# Altium schematic sheet Sheet3.SchDoc
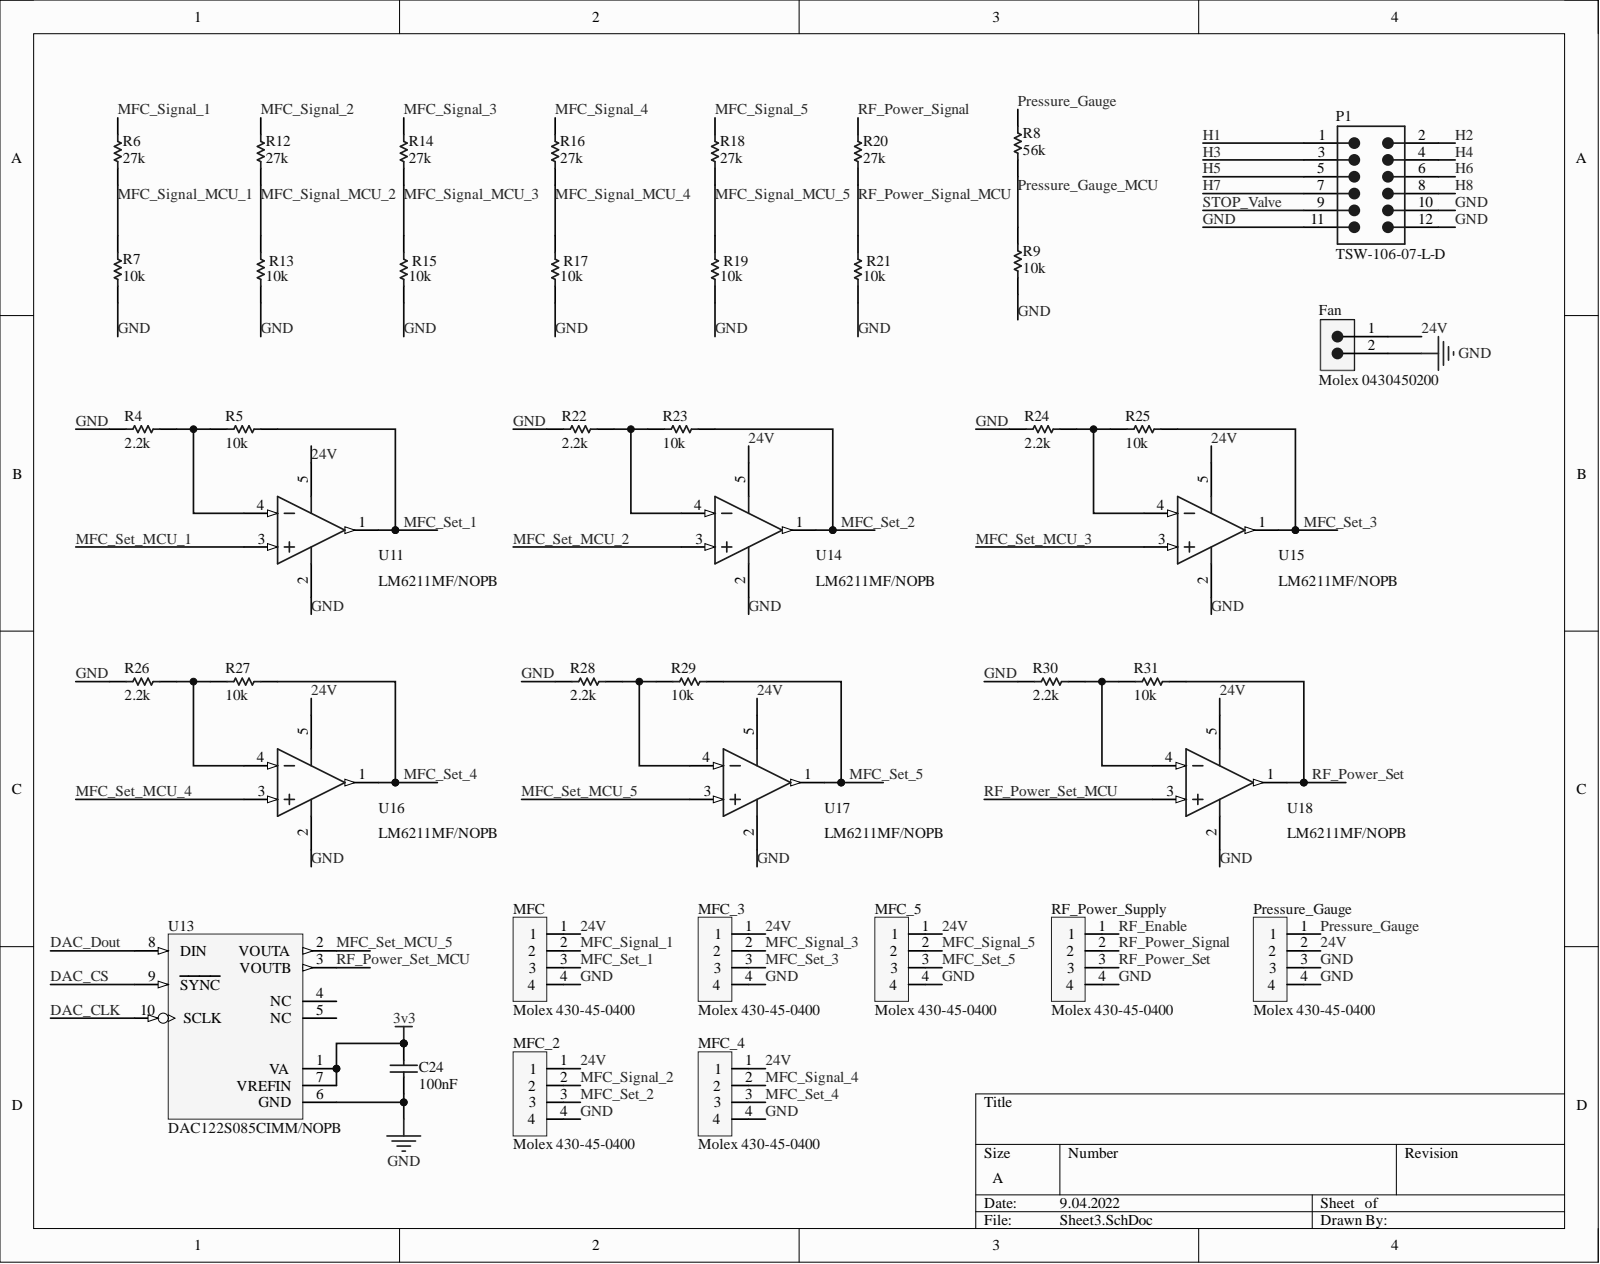
[diagram p0: Sheet3.SchDoc]

## Parts
C24  100nF  [CAPC1005X56X25NL10T15]
Fan  Molex 0430450200  [PCB-7hc2nr5bn4tgoucwa5ir-1]
MFC  Molex 430-45-0400  Manufacturer Part Number: 430-45-0400  [PCB-pgblzby65kiptvzjm08f-1]
MFC_2  Molex 430-45-0400  Manufacturer Part Number: 430-45-0400  [PCB-pgblzby65kiptvzjm08f-1]
MFC_3  Molex 430-45-0400  Manufacturer Part Number: 430-45-0400  [PCB-pgblzby65kiptvzjm08f-1]
MFC_4  Molex 430-45-0400  Manufacturer Part Number: 430-45-0400  [PCB-pgblzby65kiptvzjm08f-1]
MFC_5  Molex 430-45-0400  Manufacturer Part Number: 430-45-0400  [PCB-pgblzby65kiptvzjm08f-1]
P1  TSW-106-07-L-D  [SMTC-TSW-106-07-X-D]
Pressure_Gauge  Molex 430-45-0400  Manufacturer Part Number: 430-45-0400  [PCB-pgblzby65kiptvzjm08f-1]
R4  2.2k  [PCB-xna89c7rj2sv02b80bkg-1]
R5  10k  [PCB-xna89c7rj2sv02b80bkg-1]
R6  27k  [PCB-xna89c7rj2sv02b80bkg-1]
R7  10k  [PCB-xna89c7rj2sv02b80bkg-1]
R8  56k  [PCB-xna89c7rj2sv02b80bkg-1]
R9  10k  [PCB-xna89c7rj2sv02b80bkg-1]
R12  27k  [PCB-xna89c7rj2sv02b80bkg-1]
R13  10k  [PCB-xna89c7rj2sv02b80bkg-1]
R14  27k  [PCB-xna89c7rj2sv02b80bkg-1]
R15  10k  [PCB-xna89c7rj2sv02b80bkg-1]
R16  27k  [PCB-xna89c7rj2sv02b80bkg-1]
R17  10k  [PCB-xna89c7rj2sv02b80bkg-1]
R18  27k  [PCB-xna89c7rj2sv02b80bkg-1]
R19  10k  [PCB-xna89c7rj2sv02b80bkg-1]
R20  27k  [PCB-xna89c7rj2sv02b80bkg-1]
R21  10k  [PCB-xna89c7rj2sv02b80bkg-1]
R22  2.2k  [PCB-xna89c7rj2sv02b80bkg-1]
R23  10k  [PCB-xna89c7rj2sv02b80bkg-1]
R24  2.2k  [PCB-xna89c7rj2sv02b80bkg-1]
R25  10k  [PCB-xna89c7rj2sv02b80bkg-1]
R26  2.2k  [PCB-xna89c7rj2sv02b80bkg-1]
R27  10k  [PCB-xna89c7rj2sv02b80bkg-1]
R28  2.2k  [PCB-xna89c7rj2sv02b80bkg-1]
R29  10k  [PCB-xna89c7rj2sv02b80bkg-1]
R30  2.2k  [PCB-xna89c7rj2sv02b80bkg-1]
R31  10k  [PCB-xna89c7rj2sv02b80bkg-1]
RF_Power_Supply  Molex 430-45-0400  Manufacturer Part Number: 430-45-0400  [PCB-pgblzby65kiptvzjm08f-1]
U11  LM6211MF/NOPB  [MF05A_M]
U13  DAC122S085CIMM/NOPB  [MUB10A_M]
U14  LM6211MF/NOPB  [MF05A_M]
U15  LM6211MF/NOPB  [MF05A_M]
U16  LM6211MF/NOPB  [MF05A_M]
U17  LM6211MF/NOPB  [MF05A_M]
U18  LM6211MF/NOPB  [MF05A_M]

## Nets
3v3: C24.2 U13.1(VA) U13.7(VREFIN)
24V: Fan.1 MFC.1 MFC_2.1 MFC_3.1 MFC_4.1 MFC_5.1 Pressure_Gauge.2 U11.5 U14.5 U15.5 U16.5 U17.5 U18.5
DAC_CLK: U13.10(SCLK)
DAC_CS: U13.9(SYNC)
DAC_Dout: U13.8(DIN)
GND: C24.1 Fan.2 MFC.4 MFC_2.4 MFC_3.4 MFC_4.4 MFC_5.4 P1.10 P1.11 P1.12 Pressure_Gauge.3 Pressure_Gauge.4 R4.2 R7.2 R9.2 R13.2 R15.2 R17.2 R19.2 R21.2 R22.2 R24.2 R26.2 R28.2 R30.2 RF_Power_Supply.4 U11.2 U13.6(GND) U14.2 U15.2 U16.2 U17.2 U18.2
H1: P1.1
H2: P1.2
H3: P1.3
H4: P1.4
H5: P1.5
H6: P1.6
H7: P1.7
H8: P1.8
MFC_Set_1: MFC.3 R5.1 U11.1
MFC_Set_2: MFC_2.3 R23.1 U14.1
MFC_Set_3: MFC_3.3 R25.1 U15.1
MFC_Set_4: MFC_4.3 R27.1 U16.1
MFC_Set_5: MFC_5.3 R29.1 U17.1
MFC_Set_MCU_1: U11.3
MFC_Set_MCU_2: U14.3
MFC_Set_MCU_3: U15.3
MFC_Set_MCU_4: U16.3
MFC_Set_MCU_5: U13.2(VOUTA) U17.3
MFC_Signal_1: MFC.2 R6.1
MFC_Signal_2: MFC_2.2 R12.1
MFC_Signal_3: MFC_3.2 R14.1
MFC_Signal_4: MFC_4.2 R16.1
MFC_Signal_5: MFC_5.2 R18.1
MFC_Signal_MCU_1: R6.2 R7.1
MFC_Signal_MCU_2: R12.2 R13.1
MFC_Signal_MCU_3: R14.2 R15.1
MFC_Signal_MCU_4: R16.2 R17.1
MFC_Signal_MCU_5: R18.2 R19.1
Pressure_Gauge: Pressure_Gauge.1 R8.1
Pressure_Gauge_MCU: R8.2 R9.1
RF_Enable: RF_Power_Supply.1
RF_Power_Set: R31.1 RF_Power_Supply.3 U18.1
RF_Power_Set_MCU: U13.3(VOUTB) U18.3
RF_Power_Signal: R20.1 RF_Power_Supply.2
RF_Power_Signal_MCU: R20.2 R21.1
STOP_Valve: P1.9
NetR4_1: R4.1 R5.2 U11.4
NetR22_1: R22.1 R23.2 U14.4
NetR24_1: R24.1 R25.2 U15.4
NetR26_1: R26.1 R27.2 U16.4
NetR28_1: R28.1 R29.2 U17.4
NetR30_1: R30.1 R31.2 U18.4

Not connected: U13 4(NC) 5(NC)

## Library data of the parts
C24 = CMP-10875562-2: Capacitor 0.1 uF 16 Volt Y5 Volt -20% To 80% Surface Mount 0402 Paper Tape and Reel; Capacitance: 1e-07 F; Capacitance Tolerance: +80/-20%; Dielectric Characteristic: Y5V; Dielectric Material: Ceramic Multilayer; Lead-Free Status: Lead Free; Voltage Rating (DC): 16.0 V; Insulation Resistance: 1e+11 ?; Case/Package: 0402; Component Kind: Standard; Library Name: CommunityVault; Mounting Style: Surface Mount; Number of Pins: 2; Octopart Url: https://octopart.com/0402yg104zat2a-avx+interconnect+%2F+elco-38554; OctopartID: 7a36b33cc9caa5f1; Packaging: Cut Tape (CT); REACH SVHC Compliance: No SVHC; RoHS: Compliant; Size-Height: 0.00056 m; Size-Length: 0.001 m; Size-Thickness: 0.0008636 m; Size-Width: 0.0005 m
Fan = CMP-60dea9f3c213bf19-4: Conn Unshrouded Header HDR 2 POS 2.54mm Solder ST Thru-Hole Bag; Current Rating: 3.0 A; Component Kind: Standard; Library Name: CommunityVault
MFC MFC_2-MFC_5 Pressure_Gauge RF_Power_Supply = CMP-054fcd799a96da88-1: Conector Hembra Micro Fit 3.0 Acodado 2x2 Vias Pcb High Temperature 43045-0400 Molex; Current Rating: 5.0 A; Gender: Male; Glow Wire Compliant: Compliant; Lead-Free Status: Lead Free; Mated Height: 0.01727 m; Number of Circuits: 4; Pin Pitch: 0.003 m; Voltage Rating (AC): 250.0 V; Color: Black; Component Kind: Standard; Contact Material: Brass; Contact Plating: Tin; Flammability Rating: UL 94 V-0; Halogen Free Status: Low Halogen; Housing Color: Black; Housing Material: Plastic; Library Name: CommunityVault; Lifecycle Status: Active; Material: Polyester; Mounting Style: Solder, PCB; Number of Contacts: 4; Number of Positions: 4; Number of Rows: 2; Octopart URL: http://octopart.com/430-45-0400-molex-20757183; OctopartID: 054fcd799a96da88; Orientation: Right Angle; Packaging: Tray, Bulk; REACH SVHC Compliance: No SVHC; RoHS: Compliant; Size-Diameter: 0.003 m; Size-Height: 0.00737 m; Size-Length: 0.00965 m; Size-Width: 0.0099 m
P1 = CMP-4485796-2: 0.025" SQ Post Header, Through-hole, Vertical, -55 to 125 degC, 2.54 mm Pitch, 12-Pin, Male, RoHS; Lead-Free Status: Lead Free; Number of Contacts: 12; Pin Pitch: 0.00254 m; Component Kind: Standard; Contact Material: Phosphor Bronze; Contact Plating: Gold; Gender: Male; Housing Color: Black; Housing Material: Plastic; Library Name: CommunityVault; Mounting Style: Through Hole; Number of Positions: 12; Number of Rows: 2; Octopart Url: https://octopart.com/tsw-106-07-l-d-samtec-272251; OctopartID: eac123cfe8dc3506; REACH SVHC Compliance: No SVHC; RoHS: Compliant; Size-Height: 0.00254 m; Size-Length: 0.01524 m; Size-Width: 0.00508 m
R4-R9 R12-R31 = CMP-4716668-10: Res Thick Film 0603 10K Ohm 1% 0.1W(1/10W) ?100ppm/C SMD Automotive Paper T/R; Manufacturer Part Number: CRCW060310K0FKEA; Lead-Free Status: Lead Free; Temperature Coefficient: 100.0 ppm/°C; Voltage Rating (DC): 75.0 V; Case/Package: 0603; Component Kind: Standard; Library Name: CommunityVault; Mounting Style: Surface Mount; Octopart URL: http://octopart.com/crcw060310k0fkea-vishay-55388213; OctopartID: 4aec80ab47bf952b; Power Rating: 0.1 W; Resistance: 10k; Resistance Tolerance: ±1%; RoHS: Compliant
U11 U14-U18 = CMP-0055-01295-3: Low Noise, RRO Operational Amplifier with CMOS Input and 24V Operation, 5-pin SOT-23, Pb-Free; Bandwidth: 17000000.0 Hz; Lead-Free Status: Lead Free; Number of Channels: 1; Output Current: 0.016 A; Slew Rate: 5.5 V/μs; Input Offset Drift: 2e-06 V/K; Case/Package: SOT-23; Component Kind: Standard; Gain Bandwidth Product: 17000000.0 Hz; Library Name: CommunityVault; Lifecycle Status: Active; Mounting Style: Surface Mount; Number of Circuits: 1; Number of Pins: 5; Octopart Url: https://octopart.com/lm6211mf%2Fnopb-texas+instruments-24814988; OctopartID: 3062f812b088efa5; Packaging: Tape & Reel (TR); REACH SVHC Compliance: No SVHC; RoHS: Compliant
U13 = CMP-0061-00130-3: 12-Bit Micro Power DUAL Digital-to-Analog Converter with Rail-to-Rail Output, 10-pin Mini SOIC, Pb-Free; Lead-Free Status: Lead Free; Number of Bits: 12; Number of Channels: 2; Power Consumption: 0.0006 W; Supply Current: 0.00032 A; Case/Package: SOIC; Component Kind: Standard; Library Name: CommunityVault; Lifecycle Status: Active; Mounting Style: Surface Mount; Number of Pins: 10; Octopart Url: https://octopart.com/dac122s085cimm%2Fnopb-texas+instruments-24154027; OctopartID: 09dcc1a6a311d61b; REACH SVHC Compliance: No SVHC; RoHS: Compliant
